# Altium schematic sheet isoSPI_Interface.SchDoc
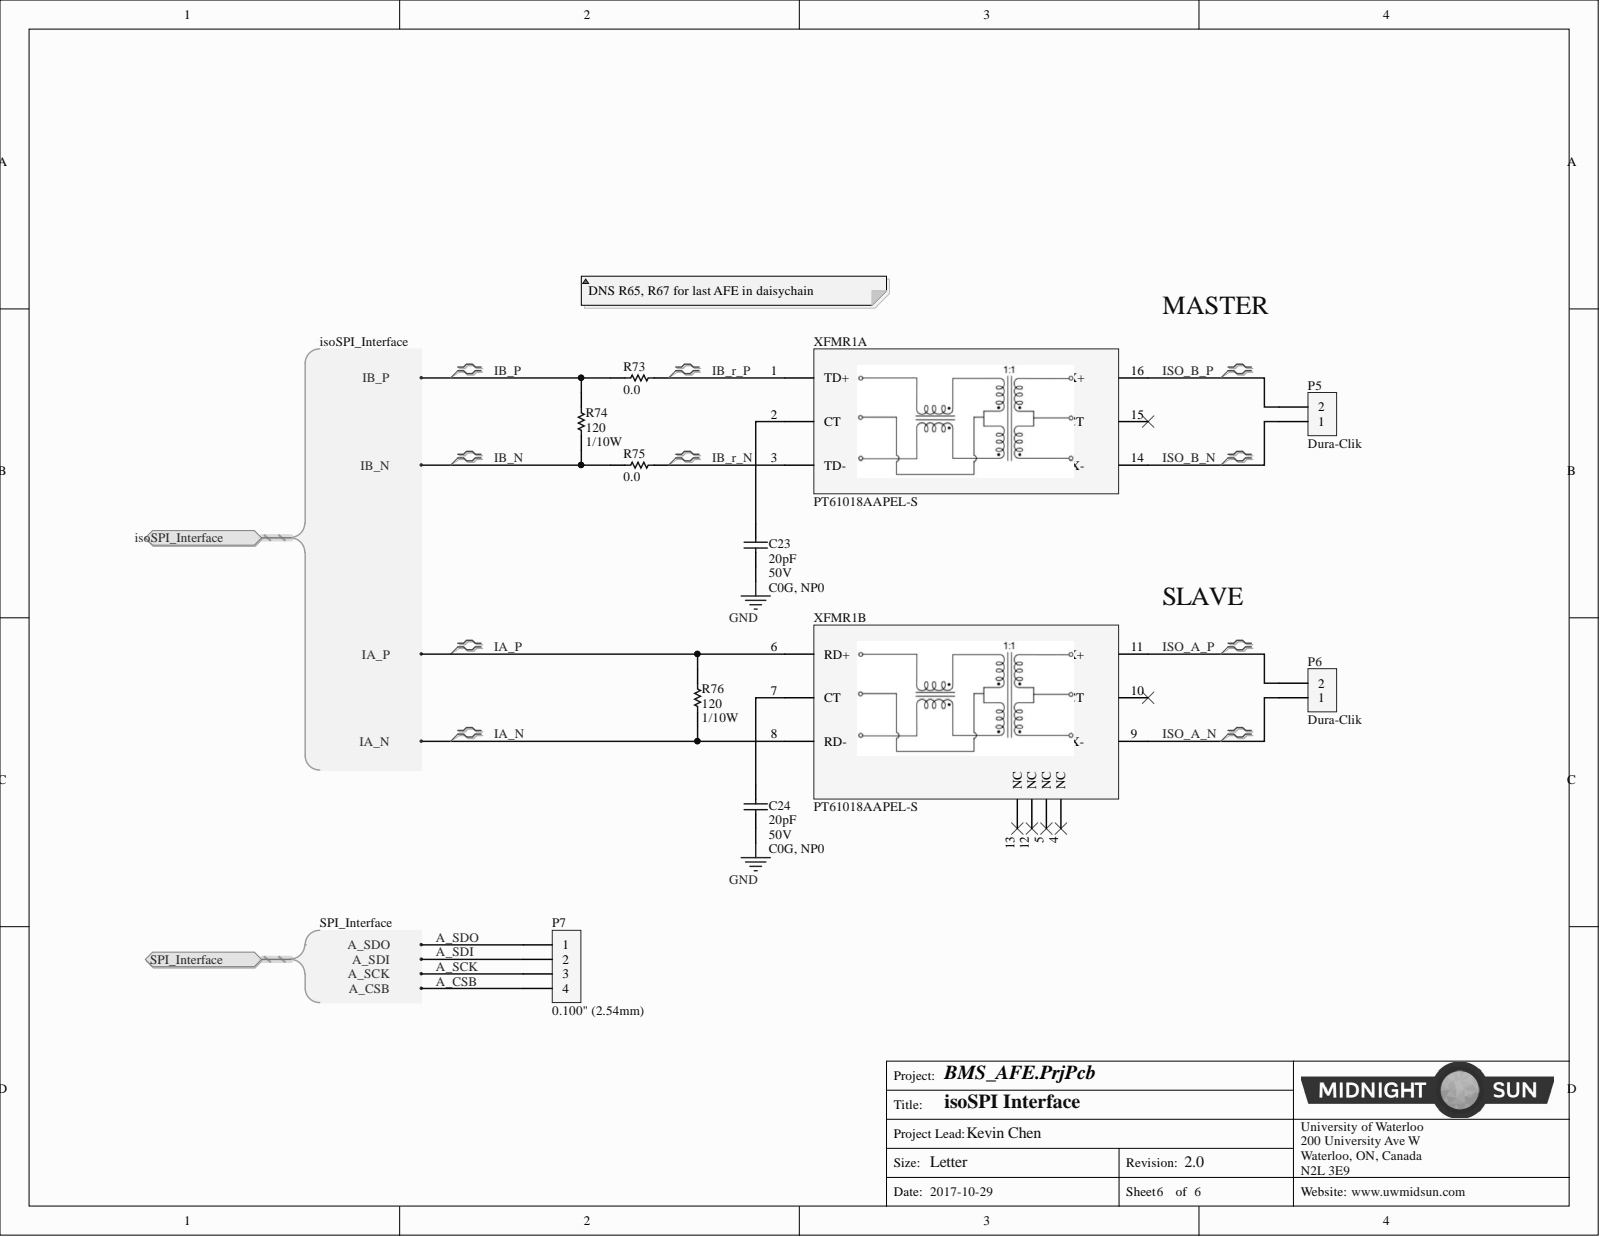
[diagram p0: isoSPI_Interface.SchDoc]

## Parts
C23  Capacitance: 20pF  Voltage: 50V  [CAP, 0603]  (hidden comment: CAP CER 20PF 50V ±5% C0G/NP0 0603)
C24  Capacitance: 20pF  Voltage: 50V  [CAP, 0603]  (hidden comment: CAP CER 20PF 50V ±5% C0G/NP0 0603)
P5  Dura-Clik  [CONN, 2POS Dura-Click Vertical]
P6  Dura-Clik  [CONN, 2POS Dura-Click Vertical]
P7  Insulation Height: 0.100" (2.54mm)  [CONN 4POS 0.100"]  (hidden comment: CONN 4POS HEADR MALE 0.1")
R73  Resistance: 0.0  [RES, 0603]  (hidden comment: RES 0.0 OHM 1/4W 0603)
R74  Power: 1/10W  [RES, 0603]  (hidden comment: RES 120 OHM 1% 1/10W 0603)
R75  Resistance: 0.0  [RES, 0603]  (hidden comment: RES 0.0 OHM 1/4W 0603)
R76  Power: 1/10W  [RES, 0603]  (hidden comment: RES 120 OHM 1% 1/10W 0603)
XFMR1  MFG_PN: PT61018AAPEL-S  [IC, PULSE XFMR 1CT:1CT 350UH SMD]  (hidden comment: IC PULSE XFMR 1CT:1CT 350UH SMD)  (parts A,B)

## Ports
ISOSPI_INTERFACE, ISOSPI_INTERFACE.IA_N, ISOSPI_INTERFACE.IA_P, ISOSPI_INTERFACE.IB_N, ISOSPI_INTERFACE.IB_P, SPI_INTERFACE, SPI_INTERFACE.A_CSB, SPI_INTERFACE.A_SCK, SPI_INTERFACE.A_SDI, SPI_INTERFACE.A_SDO

## Nets
GND: C23.2 C24.2
A_CSB: P7.4 ; port SPI_INTERFACE
A_SCK: P7.3 ; port SPI_INTERFACE
A_SDI: P7.2 ; port SPI_INTERFACE
A_SDO: P7.1 ; port SPI_INTERFACE
IA_N: R76.2 XFMR1.8(RD-) ; port ISOSPI_INTERFACE
IA_P: R76.1 XFMR1.6(RD+) ; port ISOSPI_INTERFACE
IB_N: R74.2 R75.1 ; port ISOSPI_INTERFACE
IB_P: R73.1 R74.1 ; port ISOSPI_INTERFACE
IB_R_N: R75.2 XFMR1.3(TD-)
IB_R_P: R73.2 XFMR1.1(TD+)
ISO_A_N: P6.1 XFMR1.9
ISO_A_P: P6.2 XFMR1.11
ISO_B_N: P5.1 XFMR1.14
ISO_B_P: P5.2 XFMR1.16
NetC23_1: C23.1 XFMR1.2(CT)
NetC24_1: C24.1 XFMR1.7(CT)

Not connected: XFMR1 4(NC) 5(NC) 10 12(NC) 13(NC) 15

## Library data of the parts
C23 C24 = CAP CER 20PF 50V ±5% C0G/NP0 0603: 20pF ±5% 50V Ceramic Capacitor C0G, NP0 0603 (1608 Metric); Manufacturer 1: Murata Electronics North America; Manufacturer Part Number 1: GRM1885C1H200JA01D; Component Kind: Standard; ComponentLink1Description: http://www.murata.com/~/media/webrenewal/support/library/catalog/products/capacitor/mlcc/c02e.ashx; ComponentLink1URL: http://www.murata.com/~/media/webrenewal/support/library/catalog/products/capacitor/mlcc/c02e.ashx; Height - Seated (Max): -; Lead Spacing: -; Lead Style: -; Mounting Type: Surface Mount, MLCC; Operating Temperature: -55°C ~ 125°C; Package / Case: 0603 (1608 Metric); Packaging: Cut Tape (CT); Part Status: Active; Ratings: -; Size / Dimension: 0.063" L x 0.031" W (1.60mm x 0.80mm); Supplier 1: Digi-Key; Supplier Part Number 1: 490-1410-1-ND; Temperature Coefficient: C0G, NP0; Thickness (Max): 0.035" (0.90mm); Tolerance: ±5%; Library Name: Schematic Diagrams.SchLib
P5 P6 = CONN 2POS DURA-CLIK 0.079" VERT: 2 Positions Header, Shrouded Connector 0.079" (2.00mm) Surface Mount, Vertical Tin; Manufacturer: Molex, LLC; Manufacturer Part Number: 5600200220; Mated Stacking Heights: 9.3mm; Number of Positions: 2; Number of Positions Loaded: All; Shrouding: Shrouded - 4 Wall; Style: Board to Cable/Wire; Applications: Automotive, Industrial; Category: Connectors, Interconnects; Component Kind: Standard; ComponentLink1Description: http://www.molex.com/pdm_docs/sd/5600200220_sd.pdf; ComponentLink1URL: http://www.molex.com/pdm_docs/sd/5600200220_sd.pdf; Connector Type: Header; Contact Finish - Mating: Tin; Contact Finish - Post: Tin; Contact Finish Thickness - Mating: -; Contact Finish Thickness - Post: -; Contact Length - Mating: -; Contact Length - Post: -; Contact Material: Copper Alloy; Contact Shape: Square; Contact Type: Male Pin; Current Rating: -; Fastening Type: Latch Lock; Features: Solder Retention; Ingress Protection: -; Insulation Color: Natural; Insulation Height: 0.315" (8.00mm); Insulation Material: Polyamide (PA), Nylon; Material Flammability Rating: -; Mounting Type: Surface Mount; Number of Rows: 1; Operating Temperature: -; Overall Contact Length: -; Packaging: Cut Tape (CT); Part Status: Active; Pitch - Mating: 0.079" (2.00mm); Pricing 1: 1=1.16, 10=1.012, 25=0.952, 50=0.9122, 100=0.8727, 250=0.79336 (CAD); RoHS: RoHS Compliant; Row Spacing - Mating: -; Stock 1: 11912; Supplier 1: Digi-Key; Supplier Part Number 1: WM10862CT-ND; Termination: Solder; Voltage Rating: -; Library Name: Schematic Diagrams.SchLib
P7 = CONN 4POS HEADR MALE 0.1": 4 Positions Header Connector 0.100" (2.54mm) Through Hole Gold; Manufacturer 1: Wurth Electronics Inc.; Manufacturer Part Number 1: 61300411121; Contact Length - Mating: 0.236" (6.00mm); Contact Length - Post: 0.118" (3.00mm); Current Rating: 3A; Material Flammability Rating: UL94 V-0; Number of Positions: 4; Number of Positions Loaded: All; Overall Contact Length: 0.454" (11.54mm); Shrouding: Unshrouded; Style: Board to Board or Cable; Voltage: 250V; Component Kind: Standard; ComponentLink1Description: http://katalog.we-online.de/em/datasheet/6130xx11121.pdf; ComponentLink1URL: http://katalog.we-online.de/em/datasheet/6130xx11121.pdf; Connector Type: Header; Contact Finish - Mating: Gold; Contact Finish - Post: -; Contact Finish Thickness - Mating: -; Contact Finish Thickness - Post: -; Contact Material: Copper Alloy; Contact Shape: Square; Contact Type: Male Pin; Fastening Type: -; Ingress Protection: -; Insulation Color: Black; Insulation Material: Polybutylene Terephthalate (PBT); Mated Stacking Heights: -; Mounting Type: Through Hole; Number of Rows: 1; Operating Temperature: -40°C ~ 125°C; Packaging: Bulk; Part Status: Active; Pitch - Mating: 0.100" (2.54mm); Row Spacing - Mating: -; Supplier 1: Digi-Key; Supplier Part Number 1: 732-5317-ND; Termination: Solder; Library Name: Schematic Diagrams.SchLib
R73 R75 = RES 0.0 OHM 1/4W 0603: RES SMD 0.0 OHM JUMPER 1/4W 0603; Manufacturer 1: Vishay Dale; Manufacturer Part Number 1: CRCW06030000Z0EAHP; Component Kind: Standard; ComponentLink1Description: http://www.vishay.com/docs/20043/crcwhpe3.pdf; ComponentLink1URL: http://www.vishay.com/docs/20043/crcwhpe3.pdf; Composition: Thick Film; Failure Rate: -; Height - Seated (Max): 0.022" (0.55mm); Operating Temperature: -55°C ~ 155°C; Package / Case: 0603 (1608 Metric); Packaging: Cut Tape (CT); Part Status: Active; Power (Watts): 1/4W; Size / Dimension: 0.063" L x 0.033" W (1.60mm x 0.85mm); Supplier 1: Digi-Key; Supplier Device Package: 0603; Supplier Part Number 1: 541-0.0SBCT-ND; Library Name: Schematic Diagrams.SchLib
R74 R76 = RES 120 OHM 1% 1/10W 0603: RES SMD 120 OHM 1% 1/10W 0603; Manufacturer 1: Yageo; Manufacturer Part Number 1: RC0603FR-07120RL; Resistance: 120; Temperature Coefficient: ±100ppm/°C; Component Kind: Standard; ComponentLink1Description: http://www.yageo.com/documents/recent/PYu-RC_Group_51_RoHS_L_7.pdf; ComponentLink1URL: http://www.yageo.com/documents/recent/PYu-RC_Group_51_RoHS_L_7.pdf; Composition: Thick Film; Failure Rate: -; Height - Seated (Max): 0.022" (0.55mm); Operating Temperature: -55°C ~ 155°C; Package / Case: 0603 (1608 Metric); Packaging: Cut Tape (CT); Part Status: Active; Size / Dimension: 0.063" L x 0.031" W (1.60mm x 0.80mm); Supplier 1: Digi-Key; Supplier Device Package: 0603; Supplier Part Number 1: 311-120HRCT-ND; Tolerance: ±1%; Library Name: Schematic Diagrams.SchLib
XFMR1 = IC PULSE XFMR 1CT:1CT 350UH SMD: PULSE XFMR 1CT:1CT 350UH SMD; Manufacturer: Bourns Inc.; Isolation Voltage: 1.5kVrms; Component Kind: Standard; Inductance: 350uH; Supplier: Digikey; Supplier 1: Digi-Key; Supplier_PN: PT61018AAPEL-SCT-ND; Supplier Part Number 1: PT61018AAPEL-SCT-ND; Library Name: Schematic Diagrams.SchLib
